# Altium schematic sheet SC_generator.SchDoc
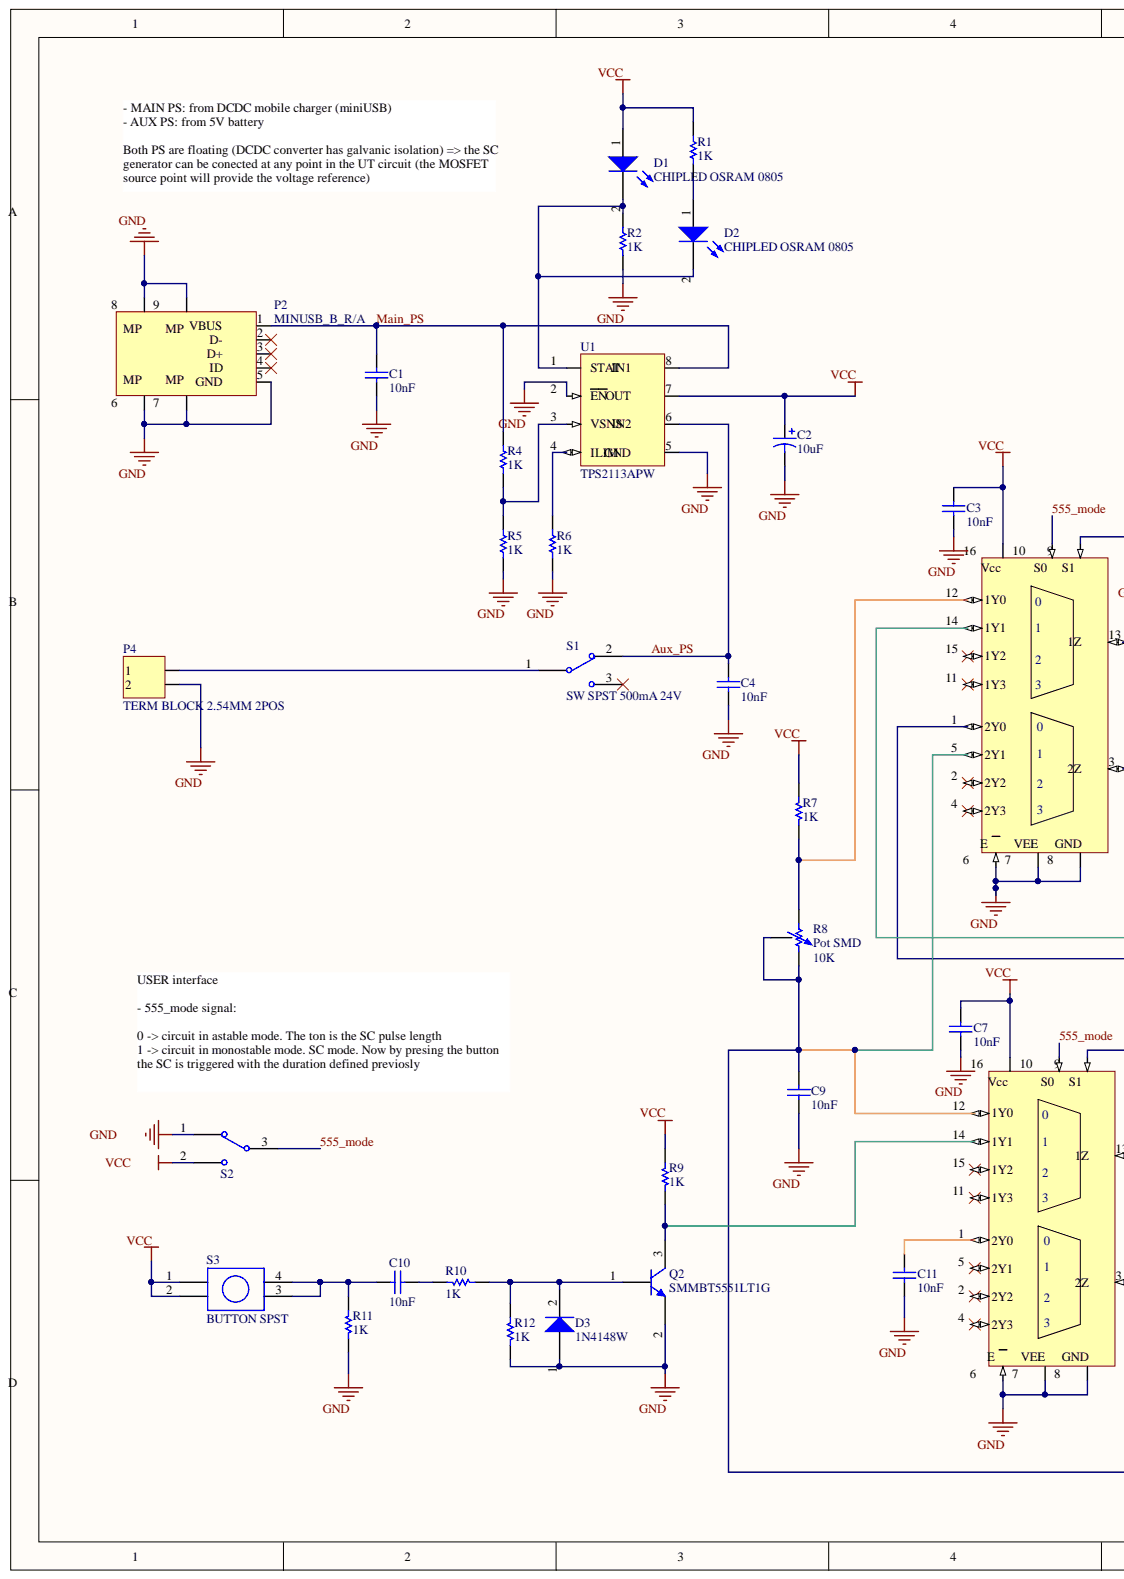
[diagram p0: SC_generator.SchDoc, left]
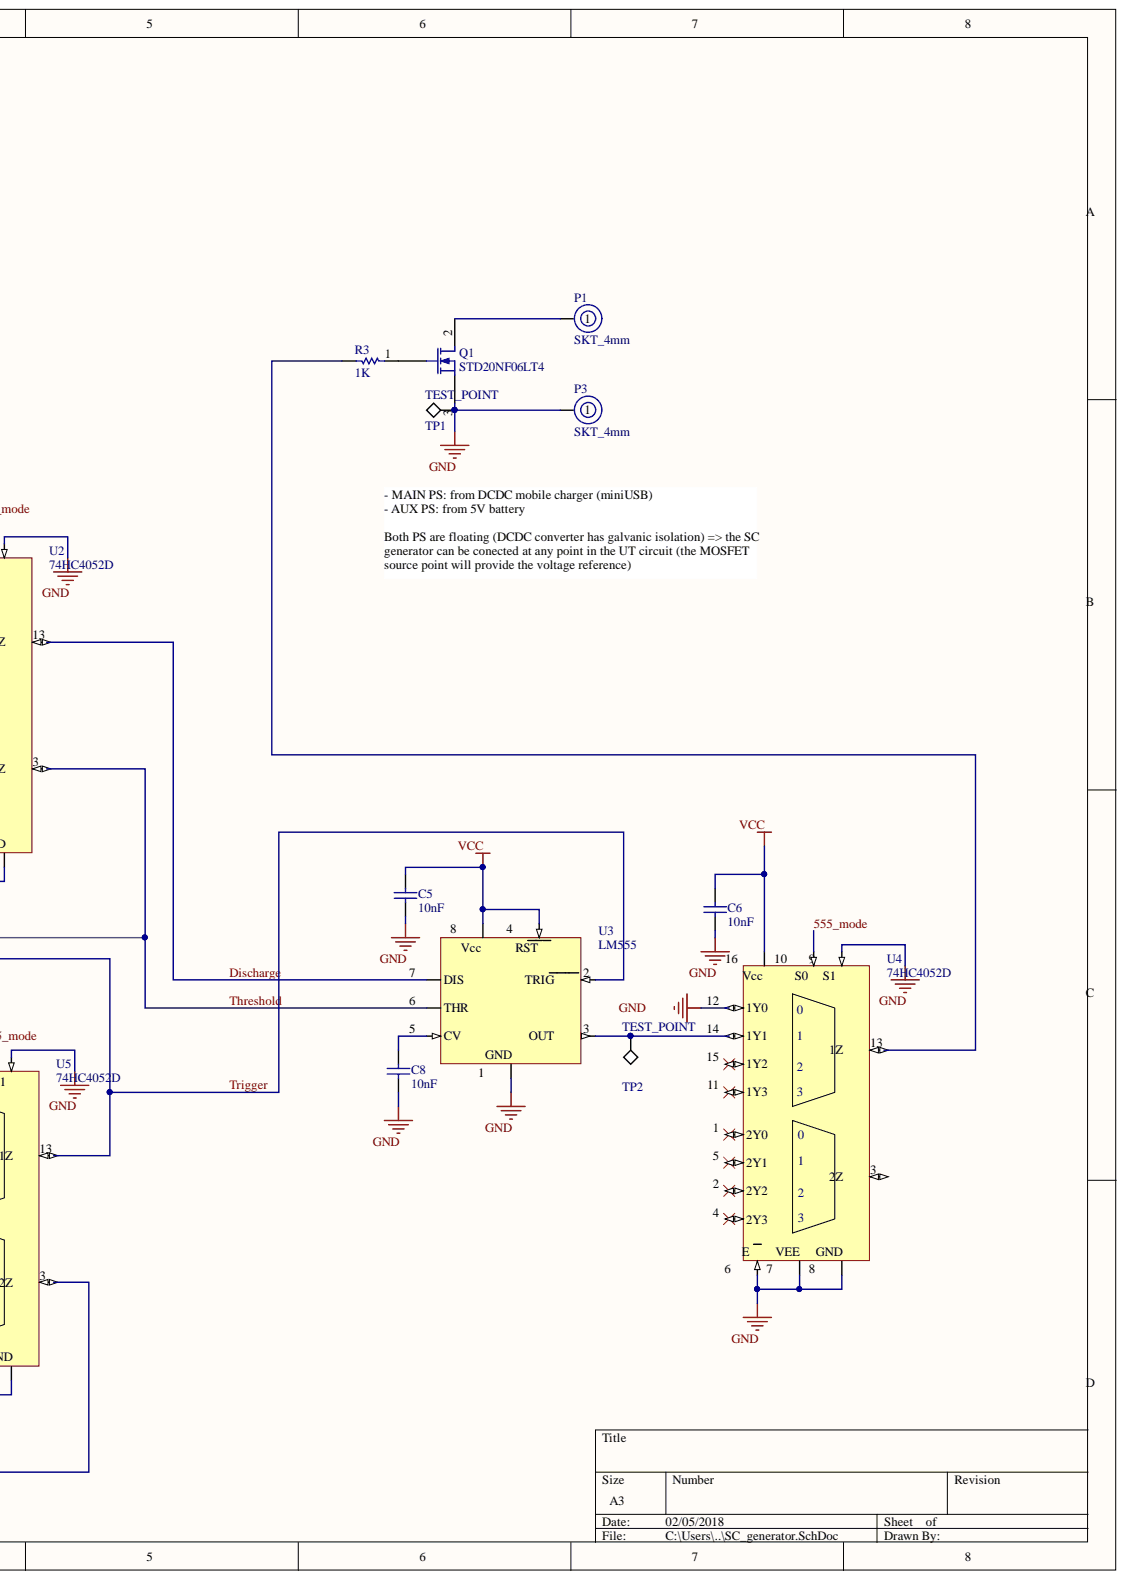
[diagram p1: SC_generator.SchDoc, right]

## Parts
C1  value 10nF  [CAP_0805_L]  (hidden comment: Cap Semi)
C2  value 10uF  [CAPAE5043X55M]  (hidden comment: Cap Electrol)
C3  value 10nF  [CAP_0805_L]  (hidden comment: Cap Semi)
C4  value 10nF  [CAP_0805_L]  (hidden comment: Cap Semi)
C5  value 10nF  [CAP_0805_L]  (hidden comment: Cap Semi)
C6  value 10nF  [CAP_0805_L]  (hidden comment: Cap Semi)
C7  value 10nF  [CAP_0805_L]  (hidden comment: Cap Semi)
C8  value 10nF  [CAP_0805_L]  (hidden comment: Cap Semi)
C9  value 10nF  [CAP_0805_L]  (hidden comment: Cap Semi)
C10  value 10nF  [CAP_0805_L]  (hidden comment: Cap Semi)
C11  value 10nF  [CAP_0805_L]  (hidden comment: Cap Semi)
D1  CHIPLED OSRAM 0805  [CHIPLED_0805_N]
D2  CHIPLED OSRAM 0805  Mfr1: OSRAM  [CHIPLED_0805_N]
D3  1N4148W  [SOD-123_M]
P1  SKT_4mm  [4MM SAFETY JACK]
P2  MINUSB_B_R/A  [CONN RECEPT MINIUSB R/A 5POS SMD]
P3  SKT_4mm  [4MM SAFETY JACK]
P4  TERM BLOCK 2.54MM 2POS  [TERM BLOCK 2.54MM 2POS]
Q1  STD20NF06LT4  [TO-252/DPAK]
Q2  SMMBT5551LT1G  [SOT-23]
R1  value 1K  [RES_1206_N]  (hidden comment: Res semi)
R2  value 1K  [RES_1206_N]  (hidden comment: Res semi)
R3  value 1K  [RES_1206_N]  (hidden comment: Res semi)
R4  value 1K  [RES_1206_N]  (hidden comment: Res semi)
R5  value 1K  [RES_1206_N]  (hidden comment: Res semi)
R6  value 1K  [RES_1206_N]  (hidden comment: Res semi)
R7  value 1K  [RES_1206_N]  (hidden comment: Res semi)
R8  Pot SMD  value 10K  [3361P_POT]
R9  value 1K  [RES_1206_N]  (hidden comment: Res semi)
R10  value 1K  [RES_1206_N]  (hidden comment: Res semi)
R11  value 1K  [RES_1206_N]  (hidden comment: Res semi)
R12  value 1K  [RES_1206_N]  (hidden comment: Res semi)
S1  SW SPST 500mA 24V  [DIP_3x1_slide_switch]
S2  SPDT SWITCH 25MA 24V  [Jumper switch SPDT]  (value not drawn)
S3  BUTTON SPST  [BUTTON SPDT]
TP1  TEST_POINT  [TP_SMD_pin]
TP2  TEST_POINT  [TP_SMD]
U1  TPS2113APW  [TSSOP-8]
U2  74HC4052D  [SO16_M]
U3  LM555  [SO-8_M]
U4  74HC4052D  [SO16_M]
U5  74HC4052D  [SO16_M]

## Nets
GND: C1.1 C2.2 C3.1 C4.1 C5.1 C6.1 C7.1 C8.1 C9.1 C11.1 D3.1 P2.5 P2.6 P2.7 P2.8 P2.9 P3.1 P4.2 Q1.3 Q2.2 R2.1 R5.1 R6.1 R11.1 R12.1 S2.1 TP1.1 U1.2(EN) U1.5 U2.6 U2.7 U2.8 U2.9 U3.1 U4.6 U4.7 U4.8 U4.9 U4.12(1Y0) U5.6 U5.7 U5.8 U5.9
VCC: C2.1 C3.2 C5.2 C6.2 C7.2 D1.1 R1.2 R7.2 R9.2 S2.2 S3.1 S3.2 U1.7 U2.16 U3.4 U3.8 U4.16 U5.16
555_MODE: S2.3 U2.10 U4.10 U5.10
AUX_PS: C4.2 S1.2 U1.6
DISCHARGE: U2.13 U3.7(DIS)
MAIN_PS: C1.2 P2.1 R4.2 U1.8
THRESHOLD: U2.3 U2.14(1Y1) U3.6(THR)
TRIGGER: U2.1(2Y0) U3.2 U5.13(1Z)
NetC8_2: C8.2 U3.5(CV)
NetC9_2: C9.2 R8.2 R8.3 U2.5(2Y1) U5.3(2Z) U5.12(1Y0)
NetC10_1: C10.1 R11.2 S3.3 S3.4
NetC10_2: C10.2 R10.2
NetC11_2: C11.2 U5.1(2Y0)
NetD1_2: D1.2 D2.2 R2.2 U1.1(STAT)
NetD2_1: D2.1 R1.1
NetD3_2: D3.2 Q2.1 R10.1 R12.2
NetP1_1: P1.1 Q1.2
NetP4_1: P4.1 S1.1
NetQ1_1: Q1.1 R3.1
NetQ2_3: Q2.3 R9.1 U5.14(1Y1)
NetR3_2: R3.2 U4.13
NetR4_1: R4.1 R5.2 U1.3(VSNS)
NetR6_2: R6.2 U1.4(ILIM)
NetR7_1: R7.1 R8.1 U2.12(1Y0)
NetTP2_1: TP2.1 U3.3 U4.14(1Y1)

Not connected: P2 2 3 4; S1 3; U2 2(2Y2) 4(2Y3) 11(1Y3) 15(1Y2); U4 1(2Y0) 2(2Y2) 3 4(2Y3) 5(2Y1) 11(1Y3) 15(1Y2); U5 2(2Y2) 4(2Y3) 5(2Y1) 11(1Y3) 15(1Y2)

## Library data of the parts
C1 C3-C11 = Cap Semi: Generic ceramic capacitor; Component Kind: Standard; Library Name: PAM_capacitors.IntLib
C2 = Cap Electrol: Generic electrolitic capacitor; Component Kind: Standard; Library Name: PAM_capacitors.IntLib
D1 D2 = CHIPLED 0805: Referencia RS: 497-4804; Component Kind: Standard; Library Name: PAM_diodes.IntLib
  D1: Mfr1: OSRAM
D3 = 1N4148W: Diodo de conmutación, 1N4148W-E3-08, 150mA, 75V, SOD-123, 2-Pines, Maximum of 50; Mfr 1: Vishay; Referencia RS: 700-3671; Component Kind: Standard; Library Name: PAM_diodes.IntLib
P1 P3 = SKT_4mm: Conector hembra de 4 mm Hirschmann 930176101, 30 V ac, 60 V dc, 32A, Rojo, Latón, Estaño, Hembra, Soldadura, 23.5mm; Mfr1: Hirschmann Test & Measurement; Referencia RS: 738-480; Component Kind: Standard; Library Name: PAM_connectors.IntLib
P2 = MINUSB_B_R/A: USB - mini B USB 2.0 OTG Receptáculo Conector 5 Posiciones Montaje en superficie, ángulo recto, orificio pasante; Mfr1: Molex; Component Kind: Standard; Library Name: PAM_connectors.IntLib; Refernecia Digikey: WM17116CT-ND
P4 = TERM BLOCK 2.54MM 2POS: 2 Position Wire to Board Terminal Block Horizontal with Board 0.100" (2.54mm) Through Hole; Mfr1: Phoenix Contact; Component Kind: Standard; Library Name: PAM_connectors.IntLib; Referencia Digi-key: 277-1273-ND
Q1 = STD20NF06LT4: Canal N Montaje en superficie 60V 24 A (Tc) 60 W (Tc) D-Pak; Mfr1: STMicroelectronics; Component Kind: Standard; Library Name: PAM_Transistors.IntLib; Referencia Digi-Key: 497-5888-1-ND
Q2 = SMMBT5551LT1G: Transistor bipolar, SMMBT5551LT1G, NPN 600 mA 160 V HFE:30 SOT-23, 3 pines,; Mfr 1: ON Semiconductor; Referencia RS: 781-5247; Component Kind: Standard; Library Name: PAM_Transistors.IntLib
R1-R7 R9-R12 = Res semi: Generic resistor; Component Kind: Standard; Library Name: PAM_resistors.IntLib
R8 = Pot SMD: Generic pot SMD; Mfr1: BOURNS; Component Kind: Standard; Library Name: PAM_resistors.IntLib; Referencia Digi-Key: 3361P-103GLFCT-ND
S1 = SW SPST TH: Interruptor SIP, SPST, Pasante, Actuador Deslizante, 500 mA a 24 V; Component Kind: Standard; Library Name: PAM_switches_buttons.IntLib
S2 = SW SPST SMD: SWITCH SLIDE DIP SPDT 25MA 24V; Mfr1: C&K; Component Kind: Standard; Library Name: PAM_switches_buttons.IntLib; Referencia Digi-key: CKN10546CT-ND
S3 = BUTTON SPST: Interruptor táctil tipo Botón, Negro, contactos SPST-NA, Montaje superficial; Mfr1: TE Connectivity; Referencia RS: 479-1508; Component Kind: Standard; Library Name: PAM_switches_buttons.IntLib
TP1 TP2 = TEST_POINT: Generic test point, several footprints; Component Kind: Standard; Library Name: PAM_connectors.IntLib
U1 = TPS2113APW: Mux de potencia TPS2113APW, 1 canales Interruptor automático 1.25A 5,5 V max. 8 pines TSSOP; Mfr 1: Texas Instruments; Referencia RS: 665-8876; Component Kind: Standard; Library Name: PAM_ICs.IntLib
U2 U4 U5 = 74HC4052D: Multiplexor/Demultiplexor 74HC4052D, Doble 4:1, 5 V, SOIC, 16 pines, 2 canales; Mfr: Nexperia; RS reference: 169-7762; Component Kind: Standard; Library Name: PAM_ICs.IntLib
U3 = LM555: IC OSC SINGLE TIMER; Component Kind: Standard; Library Name: PAM_ICs.IntLib
